# Altium schematic sheet nRF24L01.SchDoc(nRF24L01)
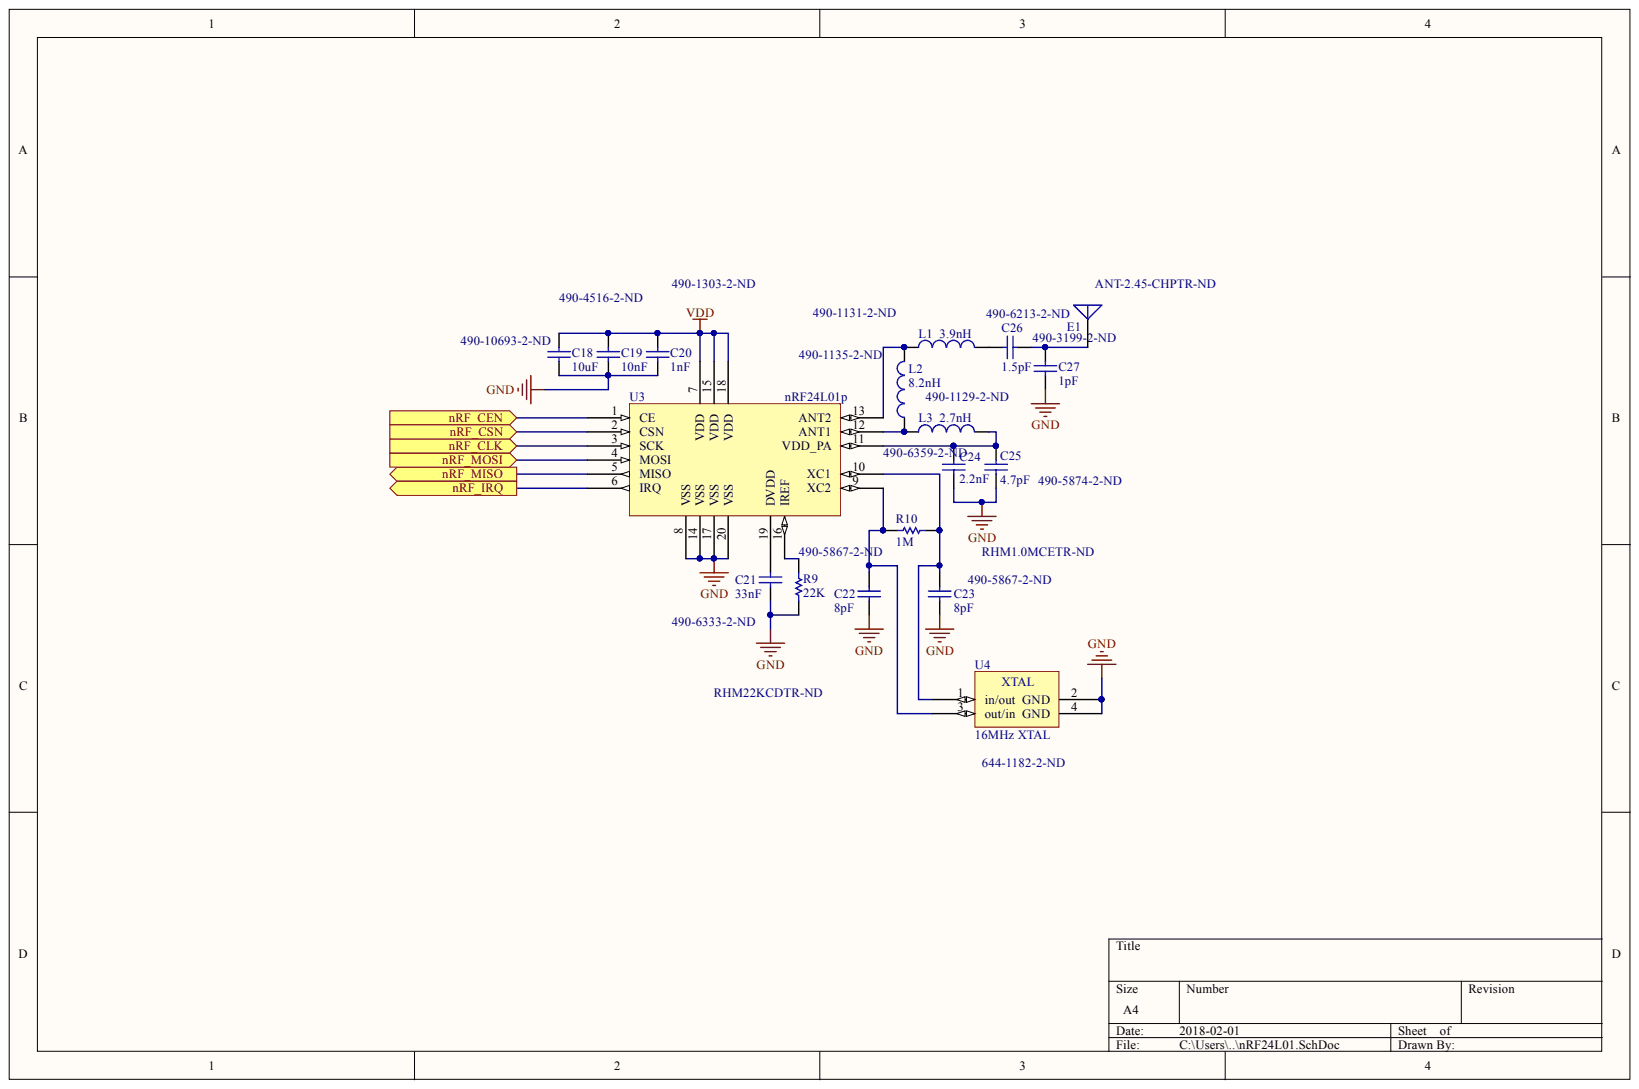
[diagram p0: nRF24L01.SchDoc]

## Parts
C18  value 10uF  Supplier Part Number 1: 490-10693-2-ND  [0402]
C19  value 10nF  [0402]
C20  value 1nF  [0402]
C21  value 33nF  Supplier Part Number 1: 490-6333-2-ND  [0402]
C22  value 8pF  [0402]
C23  value 8pF  Supplier Part Number 1: 490-5867-2-ND  [0402]
C24  value 2.2nF  Supplier Part Number 1: 490-6359-2-ND  [0402]
C25  value 4.7pF  [0402]
C26  value 1.5pF  Supplier Part Number 1: 490-6213-2-ND  [0402]
C27  value 1pF  Supplier Part Number 1: 490-3199-2-ND  [0402]
E1  Supplier Part Number 1: ANT-2.45-CHPTR-ND  [ANT-2.45-CHP-B]
L1  value 3.9nH  Supplier Part Number 1: 490-1131-2-ND  [0402]
L2  value 8.2nH  [0402]
L3  value 2.7nH  Supplier Part Number 1: 490-1129-2-ND  [0402]
R9  value 22K  [0402]
R10  value 1M  [0402]
U3  nRF24L01p  [Component_nRF]
U4  16MHz XTAL  [8Y-16.000MAAV-T]

## Ports
NRF_CEN, NRF_CLK, NRF_CSN, NRF_IRQ, NRF_MISO, NRF_MOSI

## Nets
GND: C18.1 C19.1 C20.1 C21.1 C22.1 C23.1 C24.1 C25.1 C27.2 R9.1 U3.8(VSS) U3.14(VSS) U3.17(VSS) U3.20(VSS) U4.2(GND) U4.4(GND)
VDD: C18.2 C19.2 C20.2 U3.7(VDD) U3.15(VDD) U3.18(VDD)
NetC21_2: C21.2 U3.19(DVDD)
NetC22_2: C22.2 R10.2 U3.9(XC2) U4.3(out/in)
NetC23_2: C23.2 R10.1 U3.10(XC1) U4.1(in/out)
NetC24_2: C24.2 C25.2 L3.2 U3.11(VDD_PA)
NetC26_1: C26.1 C27.1 E1.1
NetC26_2: C26.2 L1.2
NetL1_1: L1.1 L2.2 U3.13(ANT2)
NetL2_1: L2.1 L3.1 U3.12(ANT1)
NetR9_2: R9.2 U3.16(IREF)
NetU3_1: U3.1(CE) ; port NRF_CEN
NetU3_2: U3.2(CSN) ; port NRF_CSN
NetU3_3: U3.3(SCK) ; port NRF_CLK
NetU3_4: U3.4(MOSI) ; port NRF_MOSI
NetU3_5: U3.5(MISO) ; port NRF_MISO
NetU3_6: U3.6(IRQ) ; port NRF_IRQ

## Library data of the parts
C18-C27 = Cap Semi: Capacitor (Semiconductor SIM Model); Component Kind: Standard; LatestRevisionDate: 29-May-2009; LatestRevisionNote: IPC-7351 Footprint Added.; Library Name: Miscellaneous Devices.IntLib; PackageDescription: Chip Capacitor; PackageReference: C1206; Published: 8-Jun-2000; Signal Integrity: Cap Semi; Simulation: CAP; Supplier 1: Digi-Key; Publisher: Altium Limited
  C19: Supplier Part Number 1: 490-4516-2-ND
  C20: Supplier Part Number 1: 490-1303-2-ND
  C22: Supplier Part Number 1: 490-5867-2-ND
  C25: Supplier Part Number 1: 490-5874-2-ND
E1 = Antenna: Generic Antenna; Component Kind: Standard; LatestRevisionDate: 17-Jul-2002; LatestRevisionNote: Re-released for DXP Platform.; Library Name: Miscellaneous Devices.IntLib; PackageReference: PIN1; Published: 24-Mar-1999; Supplier 1: Digi-Key; Publisher: Altium Limited
L1-L3 = Inductor: Code_IEC: 1005; Code_JEITA: 0402; Component Kind: Standard; Description: Inductor; LatestRevisionDate: 29-May-2009; LatestRevisionNote: IPC-7351 Footprint Added.; Library Name: Miscellaneous Devices.IntLib; PackageDescription: Chip Inductor; PackageReference: 0402-A; Published: 8-Jun-2000; Signal Integrity: Inductor; Simulation: INDUCTOR; Supplier 1: Digi-Key; Publisher: Altium Limited
  L2: Supplier Part Number 1: 490-1135-2-ND
R9 R10 = Res Semi: Semiconductor Resistor; Component Kind: Standard; LatestRevisionDate: 17-Jul-2002; LatestRevisionNote: Re-released for DXP Platform.; Library Name: Miscellaneous Devices.IntLib; PackageDescription: Axial Device, Thru-Hole; 2 Leads; 0.5 in Pin Spacing; PackageReference: AXIAL-0.5; Published: 8-Jun-2000; Signal Integrity: Res Semi; Simulation: RES; Supplier 1: Digi-Key; Publisher: Altium Limited
  R9: Supplier Part Number 1: RHM22KCDTR-ND
  R10: Supplier Part Number 1: RHM1.0MCETR-ND
U3 = Component_nRF: Component Kind: Standard; Library Name: nRF24L01.SchLib
U4 = 8Y-16.000MAAV-T: SMD 16 MHz XTAL; Component Kind: Standard; Supplier 1: Digi-Key; Supplier Part Number 1: 644-1182-2-ND; Library Name: headstage_parts.SchLib
